# Altium schematic sheet Main.SchDoc
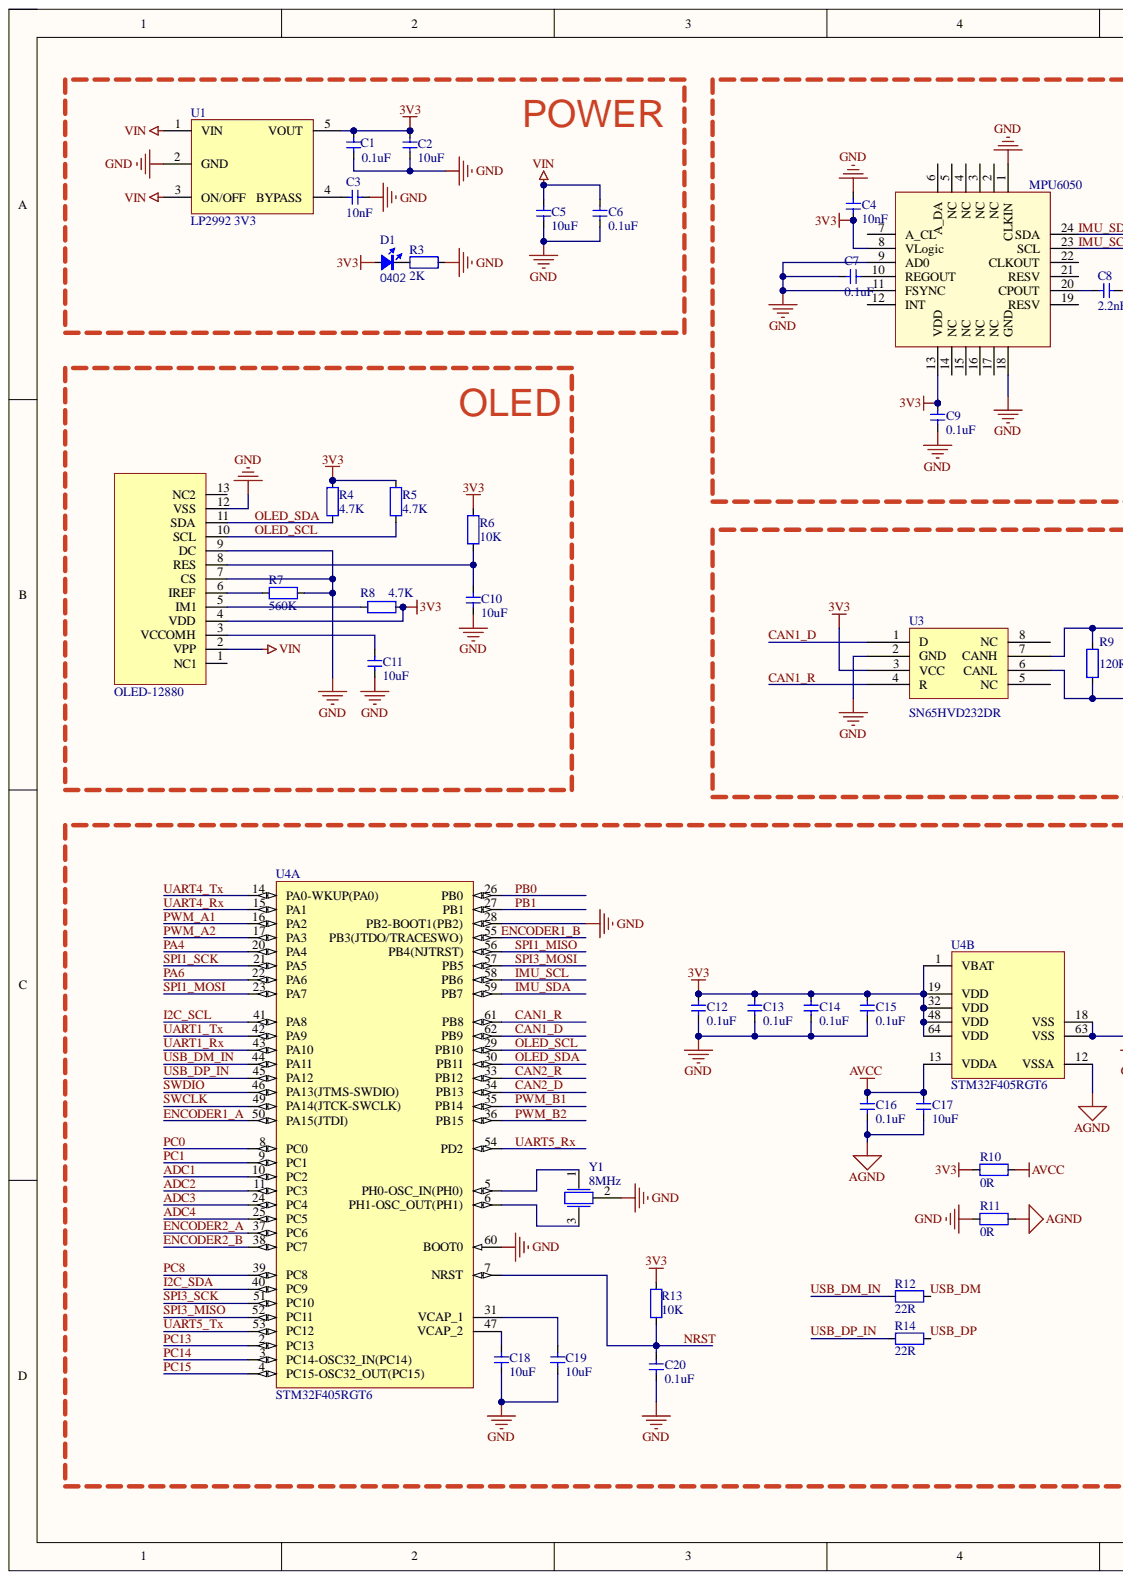
[diagram p0: Main.SchDoc, left]
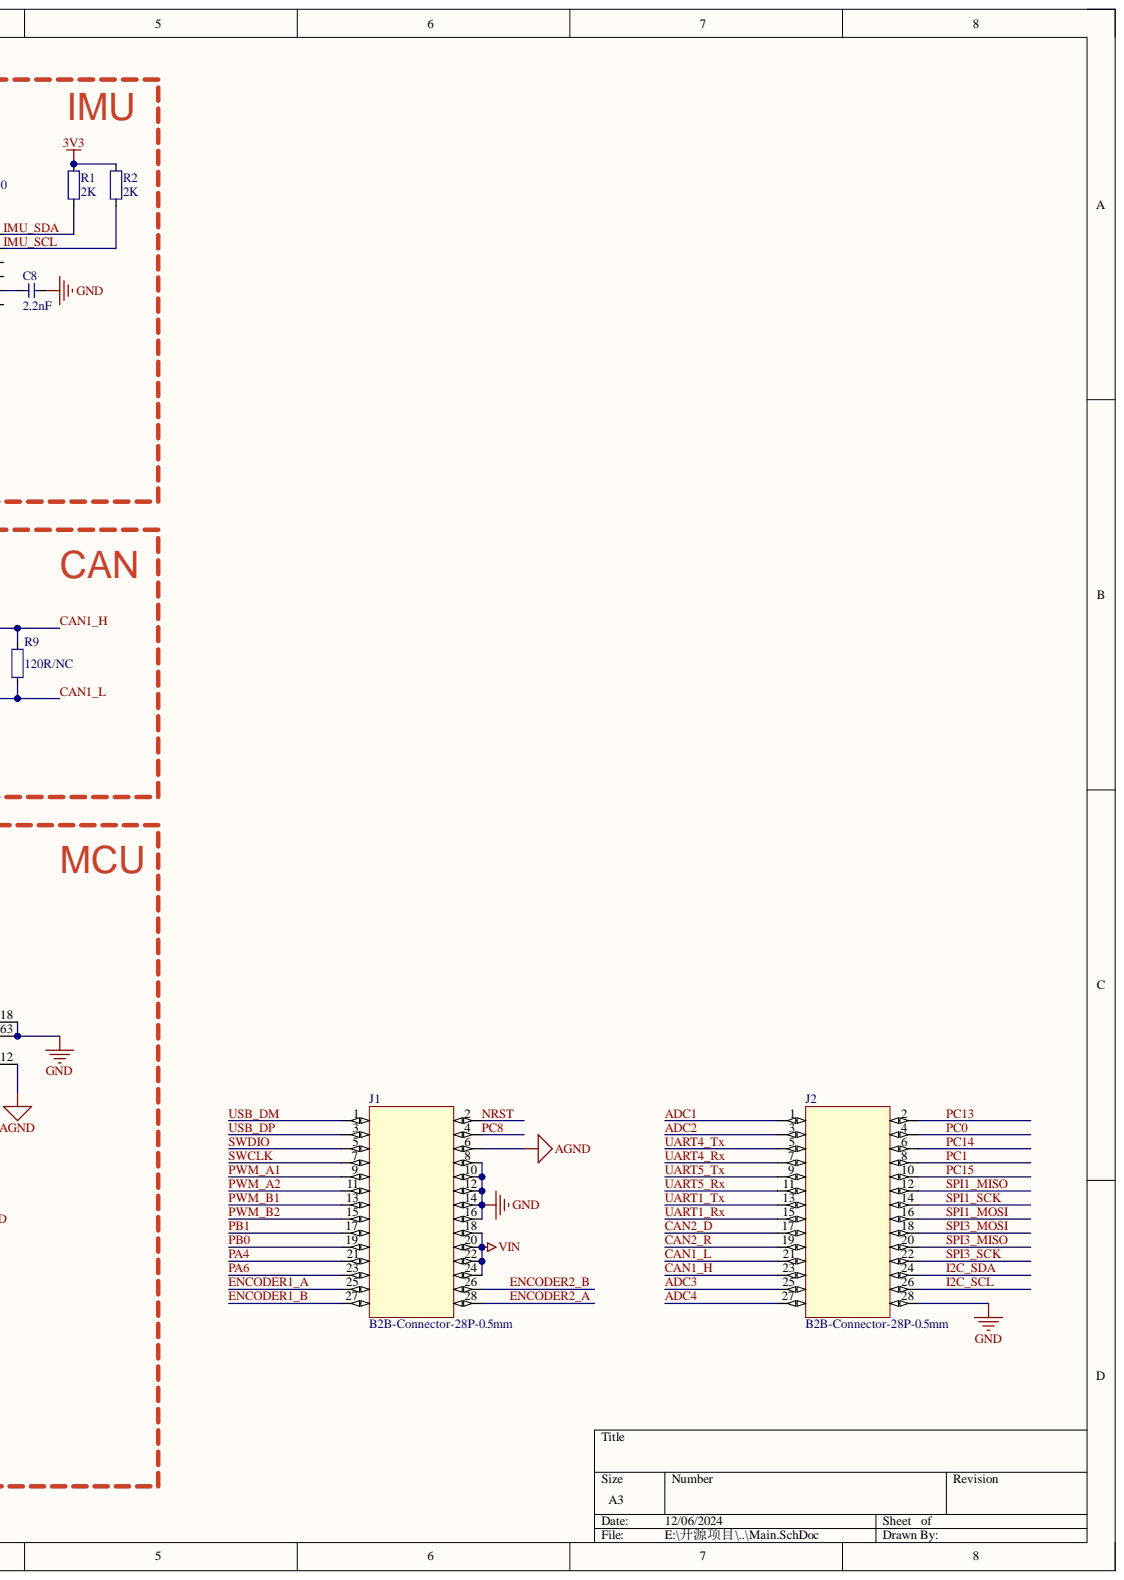
[diagram p1: Main.SchDoc, right]

## Parts
1  OLED-12880  [OLED-12880]
C1  0.1uF  [CAP_0402]
C2  10uF  [CAP_0402]
C3  10nF  [CAP_0402]
C4  10nF  [CAP_0402]
C5  10uF  [CAP_0402]
C6  0.1uF  [CAP_0402]
C7  0.1uF  [CAP_0402]
C8  2.2nF  [CAP_0402]
C9  0.1uF  [CAP_0402]
C10  10uF  [CAP_0402]
C11  10uF  [CAP_0402]
C12  0.1uF  [CAP_0402]
C13  0.1uF  [CAP_0402]
C14  0.1uF  [CAP_0402]
C15  0.1uF  [CAP_0402]
C16  0.1uF  [CAP_0402]
C17  10uF  [CAP_0402]
C18  10uF  [CAP_0402]
C19  10uF  [CAP_0402]
C20  0.1uF  [CAP_0402]
D1  Type: 0402  [LED_0402_RED]  (hidden comment: color?)
J1  B2B-Connector-28P-0.5mm  [B2B-0.5mm-28P-M]
J2  B2B-Connector-28P-0.5mm  [B2B-0.5mm-28P-M]
R1  2K  [RES_0402]
R2  2K  [RES_0402]
R3  2K  [RES_0402]
R4  4.7K  [RES_0402]
R5  4.7K  [RES_0402]
R6  10K  [RES_0402]
R7  560K  [RES_0402]
R8  4.7K  [RES_0402]
R9  120R/NC  [RES_0402]
R10  0R  [RES_0402]
R11  0R  [RES_0402]
R12  22R  [RES_0402]
R13  10K  [RES_0402]
R14  22R  [RES_0402]
U1  LP2992 3V3  [SOT23-5]
U2  MPU6050  [QFN-24]
U3  SN65HVD232D  Manufacturer Part Number: SN65HVD232DR  [SO-8]
U4  STM32F405RGT6  [LQFP-64_N]  (parts A,B)
Y1  8MHz  [晶振-3P]

## Nets
3V3: 1.4(VDD) C1.2 C2.2 C4.1 C9.2 C12.2 C13.2 C14.2 C15.2 D1.1 R1.2 R2.2 R4.2 R5.2 R6.2 R8.2 R10.2 R13.2 U1.5(VOUT) U2.8(VLogic) U2.13(VDD) U3.3(VCC) U4.1(VBAT) U4.19(VDD) U4.32(VDD) U4.48(VDD) U4.64(VDD)
AGND: C16.1 C17.1 J1.6 R11.1 U4.12(VSSA)
AVCC: C16.2 C17.2 R10.1 U4.13(VDDA)
GND: 1.7(CS) 1.9(DC) 1.12(VSS) C1.1 C2.1 C3.1 C4.2 C5.1 C6.1 C7.2 C8.1 C9.1 C10.1 C11.1 C12.1 C13.1 C14.1 C15.1 C18.1 C19.1 C20.1 J1.8 J1.10 J1.12 J1.14 J1.16 J2.28 R3.1 R7.2 R11.2 U1.2(GND) U2.1(CLKIN) U2.9(AD0) U2.11(FSYNC) U2.18(GND) U3.2(GND) U4.18(VSS) U4.28(PB2-BOOT1(PB2)) U4.60(BOOT0) U4.63(VSS) Y1.2
VIN: 1.2(VPP) C5.2 C6.2 J1.18 J1.20 J1.22 J1.24 U1.1(VIN) U1.3(ON/OFF)
ADC1_1: J2.1 U4.10(PC2)  [also labelled ADC1]
ADC2_1: J2.3 U4.11(PC3)  [also labelled ADC2]
ADC3_1: J2.25 U4.24(PC4)  [also labelled ADC3]
ADC4_1: J2.27 U4.25(PC5)  [also labelled ADC4]
CAN1_D_1: U3.1(D) U4.62(PB9)  [also labelled CAN1_D]
CAN1_H_1: J2.23 R9.1 U3.7(CANH)  [also labelled CAN1_H]
CAN1_L_1: J2.21 R9.2 U3.6(CANL)  [also labelled CAN1_L]
CAN1_R_1: U3.4(R) U4.61(PB8)  [also labelled CAN1_R]
CAN2_D_1: J2.17 U4.34(PB13)  [also labelled CAN2_D]
CAN2_R_1: J2.19 U4.33(PB12)  [also labelled CAN2_R]
ENCODER1_A_1: J1.25 U4.50(PA15(JTDI))  [also labelled ENCODER1_A]
ENCODER1_B_1: J1.27 U4.55(PB3(JTDO/TRACESWO))  [also labelled ENCODER1_B]
ENCODER2_A_1: J1.28 U4.37(PC6)  [also labelled ENCODER2_A]
ENCODER2_B_1: J1.26 U4.38(PC7)  [also labelled ENCODER2_B]
I2C_SCL_1: J2.26 U4.41(PA8)  [also labelled I2C_SCL]
I2C_SDA_1: J2.24 U4.40(PC9)  [also labelled I2C_SDA]
IMU_SCL_1: R2.1 U2.23(SCL) U4.58(PB6)  [also labelled IMU_SCL]
IMU_SDA_1: R1.1 U2.24(SDA) U4.59(PB7)  [also labelled IMU_SDA]
NRST_1: C20.2 J1.2 R13.1 U4.7(NRST)  [also labelled NRST]
OLED_SCL_1: 1.10(SCL) R5.1 U4.29(PB10)  [also labelled OLED_SCL]
OLED_SDA_1: 1.11(SDA) R4.1 U4.30(PB11)  [also labelled OLED_SDA]
PA4_1: J1.21 U4.20(PA4)  [also labelled PA4]
PA6_1: J1.23 U4.22(PA6)  [also labelled PA6]
PB0_1: J1.19 U4.26(PB0)  [also labelled PB0]
PB1_1: J1.17 U4.27(PB1)  [also labelled PB1]
PC0_1: J2.4 U4.8(PC0)  [also labelled PC0]
PC1_1: J2.8 U4.9(PC1)  [also labelled PC1]
PC8_1: J1.4 U4.39(PC8)  [also labelled PC8]
PC13_1: J2.2 U4.2(PC13)  [also labelled PC13]
PC14_1: J2.6 U4.3(PC14-OSC32_IN(PC14))  [also labelled PC14]
PC15_1: J2.10 U4.4(PC15-OSC32_OUT(PC15))  [also labelled PC15]
PWM_A1_1: J1.9 U4.16(PA2)  [also labelled PWM_A1]
PWM_A2_1: J1.11 U4.17(PA3)  [also labelled PWM_A2]
PWM_B1_1: J1.13 U4.35(PB14)  [also labelled PWM_B1]
PWM_B2_1: J1.15 U4.36(PB15)  [also labelled PWM_B2]
SPI1_MISO_1: J2.12 U4.56(PB4(NJTRST))  [also labelled SPI1_MISO]
SPI1_MOSI_1: J2.16 U4.23(PA7)  [also labelled SPI1_MOSI]
SPI1_SCK_1: J2.14 U4.21(PA5)  [also labelled SPI1_SCK]
SPI3_MISO_1: J2.20 U4.52(PC11)  [also labelled SPI3_MISO]
SPI3_MOSI_1: J2.18 U4.57(PB5)  [also labelled SPI3_MOSI]
SPI3_SCK_1: J2.22 U4.51(PC10)  [also labelled SPI3_SCK]
SWCLK_1: J1.7 U4.49(PA14(JTCK-SWCLK))  [also labelled SWCLK]
SWDIO_1: J1.5 U4.46(PA13(JTMS-SWDIO))  [also labelled SWDIO]
UART1_Rx_1: J2.15 U4.43(PA10)  [also labelled UART1_Rx]
UART1_Tx_1: J2.13 U4.42(PA9)  [also labelled UART1_Tx]
UART4_Rx_1: J2.7 U4.15(PA1)  [also labelled UART4_Rx]
UART4_Tx_1: J2.5 U4.14(PA0-WKUP(PA0))  [also labelled UART4_Tx]
UART5_Rx_1: J2.11 U4.54(PD2)  [also labelled UART5_Rx]
UART5_Tx_1: J2.9 U4.53(PC12)  [also labelled UART5_Tx]
USB_DM_1: J1.1 R12.1  [also labelled USB_DM]
USB_DM_IN_1: R12.2 U4.44(PA11)  [also labelled USB_DM_IN]
USB_DP_1: J1.3 R14.1  [also labelled USB_DP]
USB_DP_IN_1: R14.2 U4.45(PA12)  [also labelled USB_DP_IN]
Net1_3: 1.3(VCCOMH) C11.2
Net1_5: 1.5(IM1) R8.1
Net1_6: 1.6(IREF) R7.1
Net1_8: 1.8(RES) C10.2 R6.1
NetC3_2: C3.2 U1.4(BYPASS)
NetC7_1: C7.1 U2.10(REGOUT)
NetC8_2: C8.2 U2.20(CPOUT)
NetC18_2: C18.2 U4.47(VCAP_2)
NetC19_2: C19.2 U4.31(VCAP_1)
NetD1_2: D1.2 R3.2
NetU4_5: U4.5(PH0-OSC_IN(PH0)) Y1.1
NetU4_6: U4.6(PH1-OSC_OUT(PH1)) Y1.3

Not connected: 1 1(NC1) 13(NC2); U2 2(NC) 3(NC) 4(NC) 5(NC) 6(A_DA) 7(A_CL) 12(INT) 14(NC) 15(NC) 16(NC) 17(NC) 19(RESV) 21(RESV) 22(CLKOUT); U3 5(NC) 8(NC)

## Library data of the parts
C1-C20 = 电容-0402: Capacitor; Value: 0.1u; Component Kind: Standard; Library Name: MyPcbLib.IntLib
D1 = LED_0402: LED; Component Kind: Standard; Library Name: KitSprout.IntLib; Value: color?
R1-R11 R13 = 电阻-0402: Resistor; Value: 22R; Component Kind: Standard; Library Name: MyPcbLib.IntLib
R12 R14 = 电阻-0402: Resistor; Component Kind: Standard; Library Name: MyPcbLib.IntLib; Value: 22R
U1 = LP2992: LDO; Component Kind: Standard; Library Name: MyPcbLib.IntLib
U2 = MPU6050: 六轴传感器; Component Kind: Standard; Library Name: mLib.SchLib
U3 = SN65HVD232D: Manufacturer: Texas Instruments; Data Rate: 1Mbps; Duplex: Half; Number of Drivers/Receivers: 1/1; Protocol: CAN; Voltage - Supply: 3 V ~ 3.6 V; Category: Integrated Circuits (ICs); Component Kind: Standard; ComponentLink1Description: http://www.ti.com/lit/ds/symlink/sn65hvd230.pdf; ComponentLink1URL: http://www.ti.com/lit/ds/symlink/sn65hvd230.pdf; Library Name: MyPcbLib.IntLib; Mounting Type: Surface Mount; Operating Temperature: -40°C ~ 85°C; Package / Case: 8-SOIC (0.154", 3.90mm Width); Packaging: Tape & Reel (TR); Part Status: Active; Pricing 1: 2500=0.84375 (USD); Pricing 2: 1=2.05, 10=1.842, 100=1.4809, 500=1.21668, 1000=1.00811 (USD); RoHS: RoHS Compliant; Stock: 5000; Supplier 1: Digi-Key; Supplier 2: Digi-Key; Supplier Device Package: 8-SOIC; Supplier Part Number 1: 296-26344-2-ND; Supplier Part Number 2: 296-26344-1-ND; Type: Transceiver
U4 = STM32F405RGT6: ARM Cortex-M4 32-bit MCU+FPU, 210 DMIPS, 1024 kB Flash, 192 kB Internal RAM, 51 I/Os, 64-pin LQFP, -40 to 85 degC, Tray; Manufacturer: STMicroelectronics; D/A Converter: 2x12-bit; FLASH Size (Prog) (kB): 1024; I/Os (High Current): 51; Internal RAM Size (kB): 192; Operating Frequency(F) (Processor speed) (MHz): 168; Supply Voltage(Vcc) max (V): 3.6; Supply Voltage(Vcc) min (V): 1.8; Component Kind: Standard; ComponentLink1Description: Manufacturer URL; ComponentLink1URL: http://www.st.com/web/en/home.html; ComponentLink2Description: Datasheet; ComponentLink2URL: http://www.st.com/st-web-ui/static/active/en/resource/technical/document/datasheet/DM00037051.pdf; ComponentLink3Description: Package Specification; ComponentLink3URL: http://www.st.com/st-web-ui/static/active/en/resource/technical/document/datasheet/DM00037051.pdf; Core: ARM Cortex-M4; DatasheetVersion: Rev. 4; Library Name: STMicroelectronics STM32 F4.IntLib; Mounting Technology: Surface Mount; PackageDescription: 64-Pin Low Profile Quad Flat Package, 10 x 10 mm Body, 0.5 mm Pitch; PackageReference: LQFP64; PackageVersion: Rev. 4, 06/2013; Packing: Tray; PartNumber: STM32F405RGT6; Supplier 1: Digi-Key; Supplier 2: Farnell; Supplier 3: Newark; Supplier 4: Mouser; Supplier Part Number 1: 497-11767-ND; Supplier Part Number 2: 2064363; Supplier Part Number 3: 73T2329; Supplier Part Number 4: 511-STM32F405RGT6
Y1 = 晶振-3Pin: 村田贴片; Component Kind: Standard; Library Name: MyPcbLib.IntLib
1 = OLED-12880: Component Kind: Standard; Library Name: mLib.SchLib
J1 J2 = B2B-Connector-28P-0.5mm: Component Kind: Standard; Library Name: MyPcbLib.IntLib
